# Altium schematic sheet Plumo-2D.SchDoc
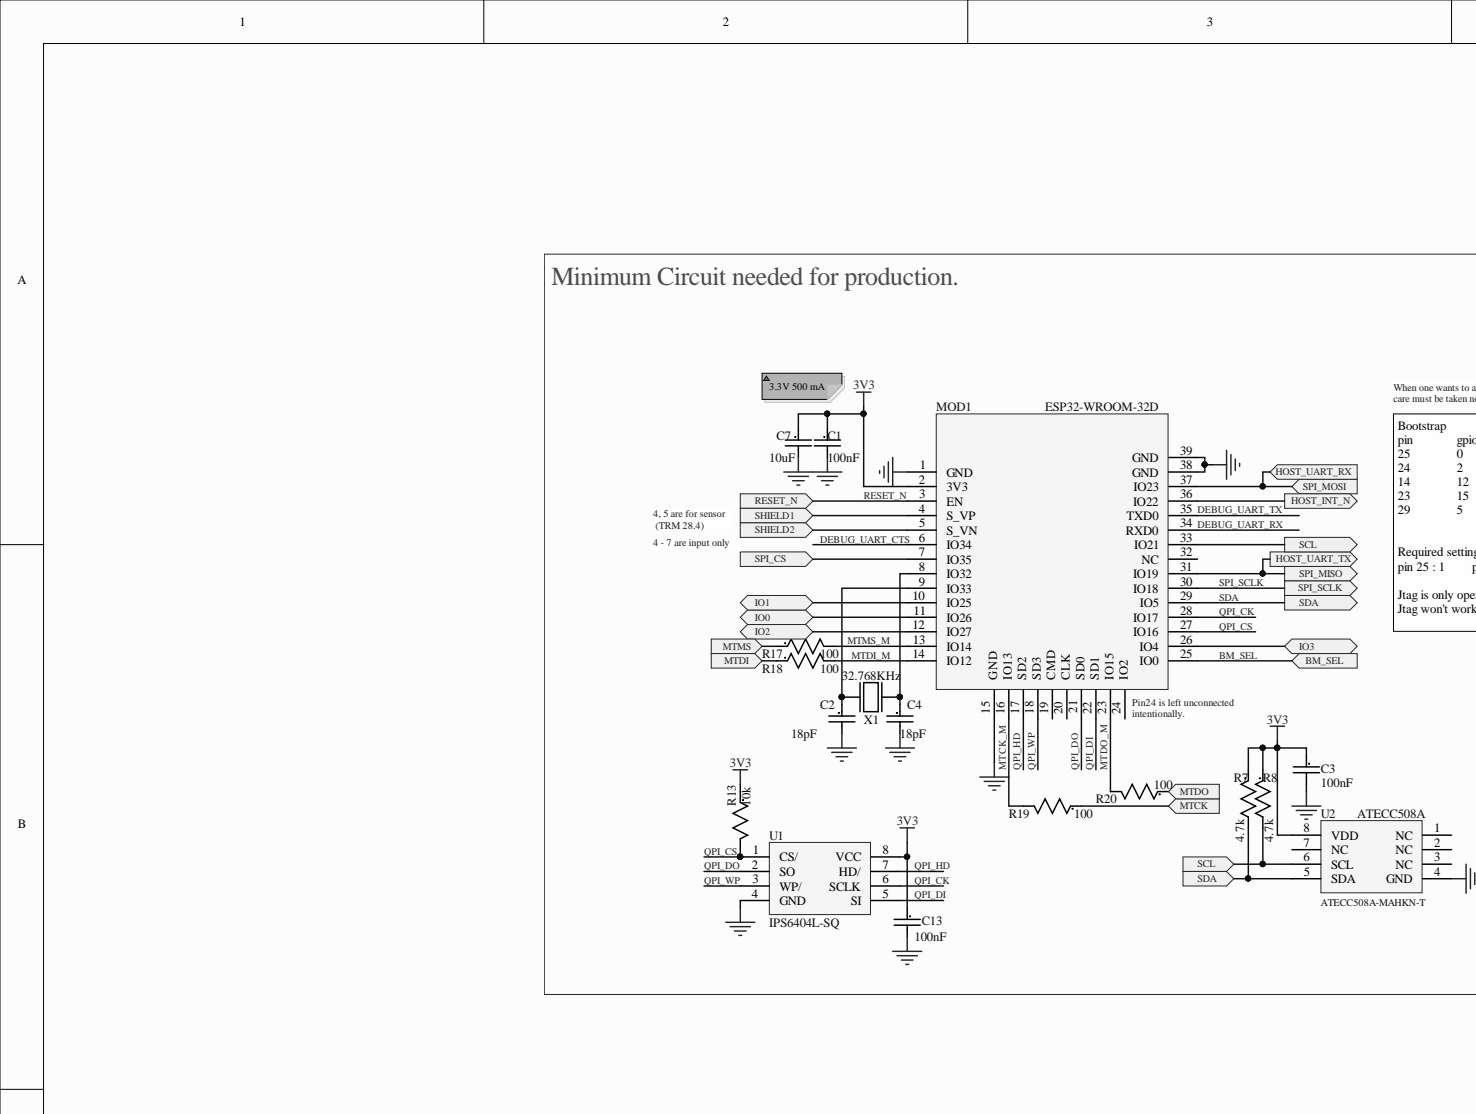
[diagram p0: Plumo-2D.SchDoc, top left]
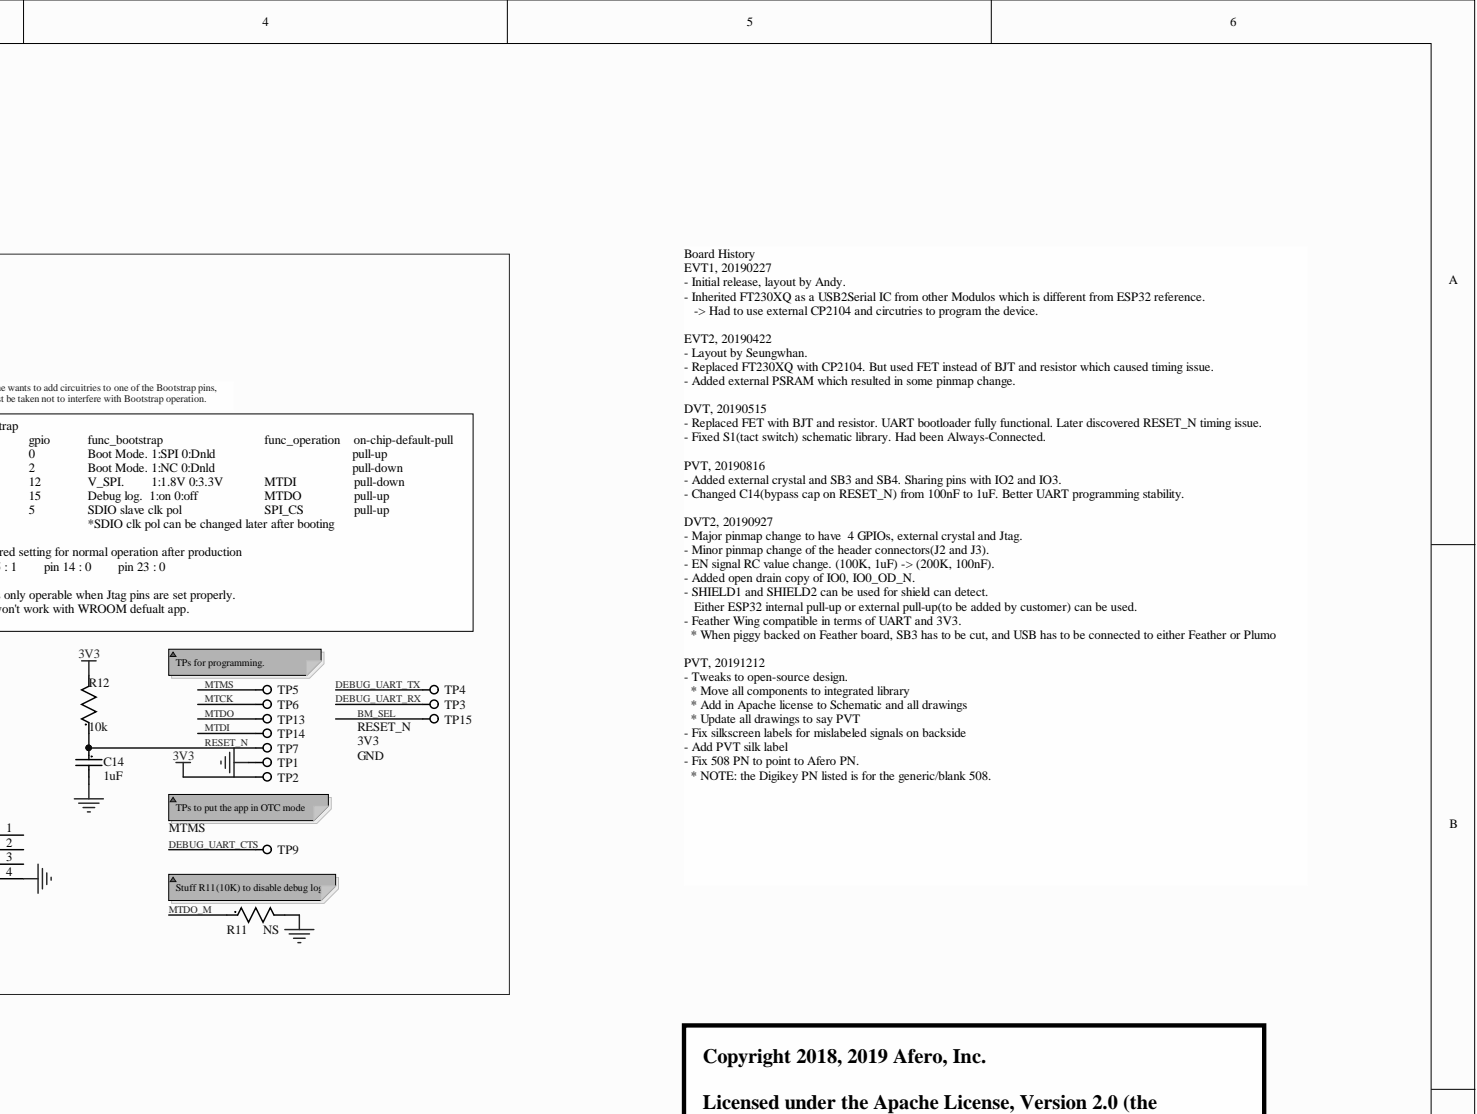
[diagram p1: Plumo-2D.SchDoc, top right]
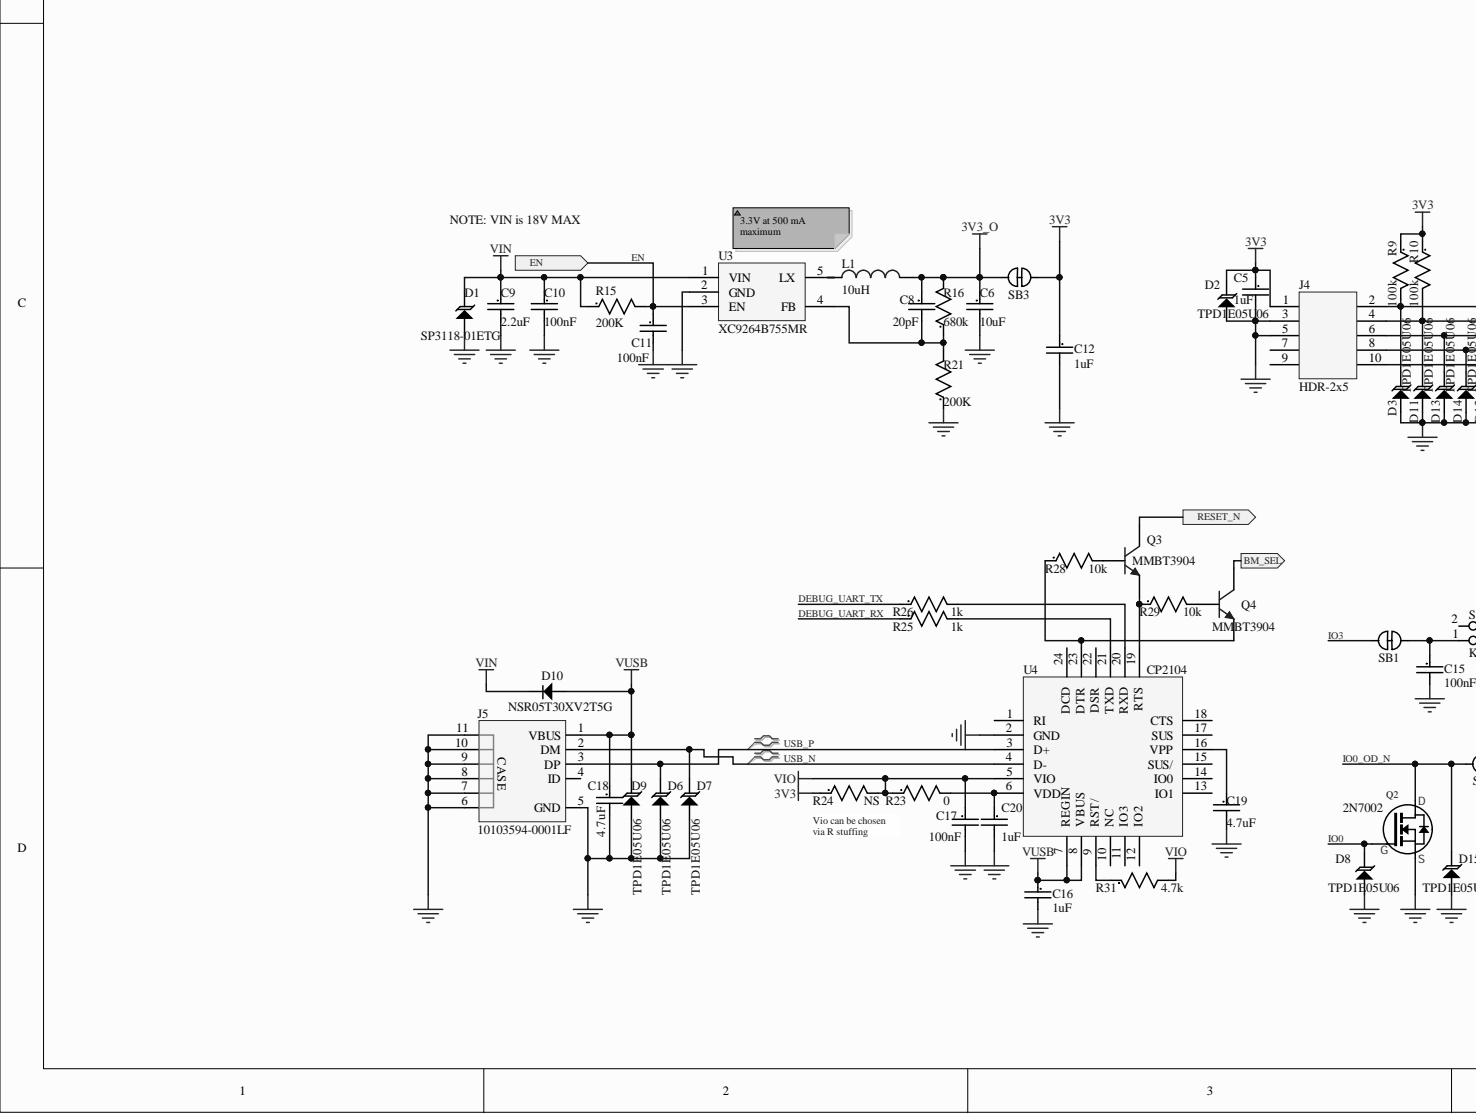
[diagram p2: Plumo-2D.SchDoc, bottom left]
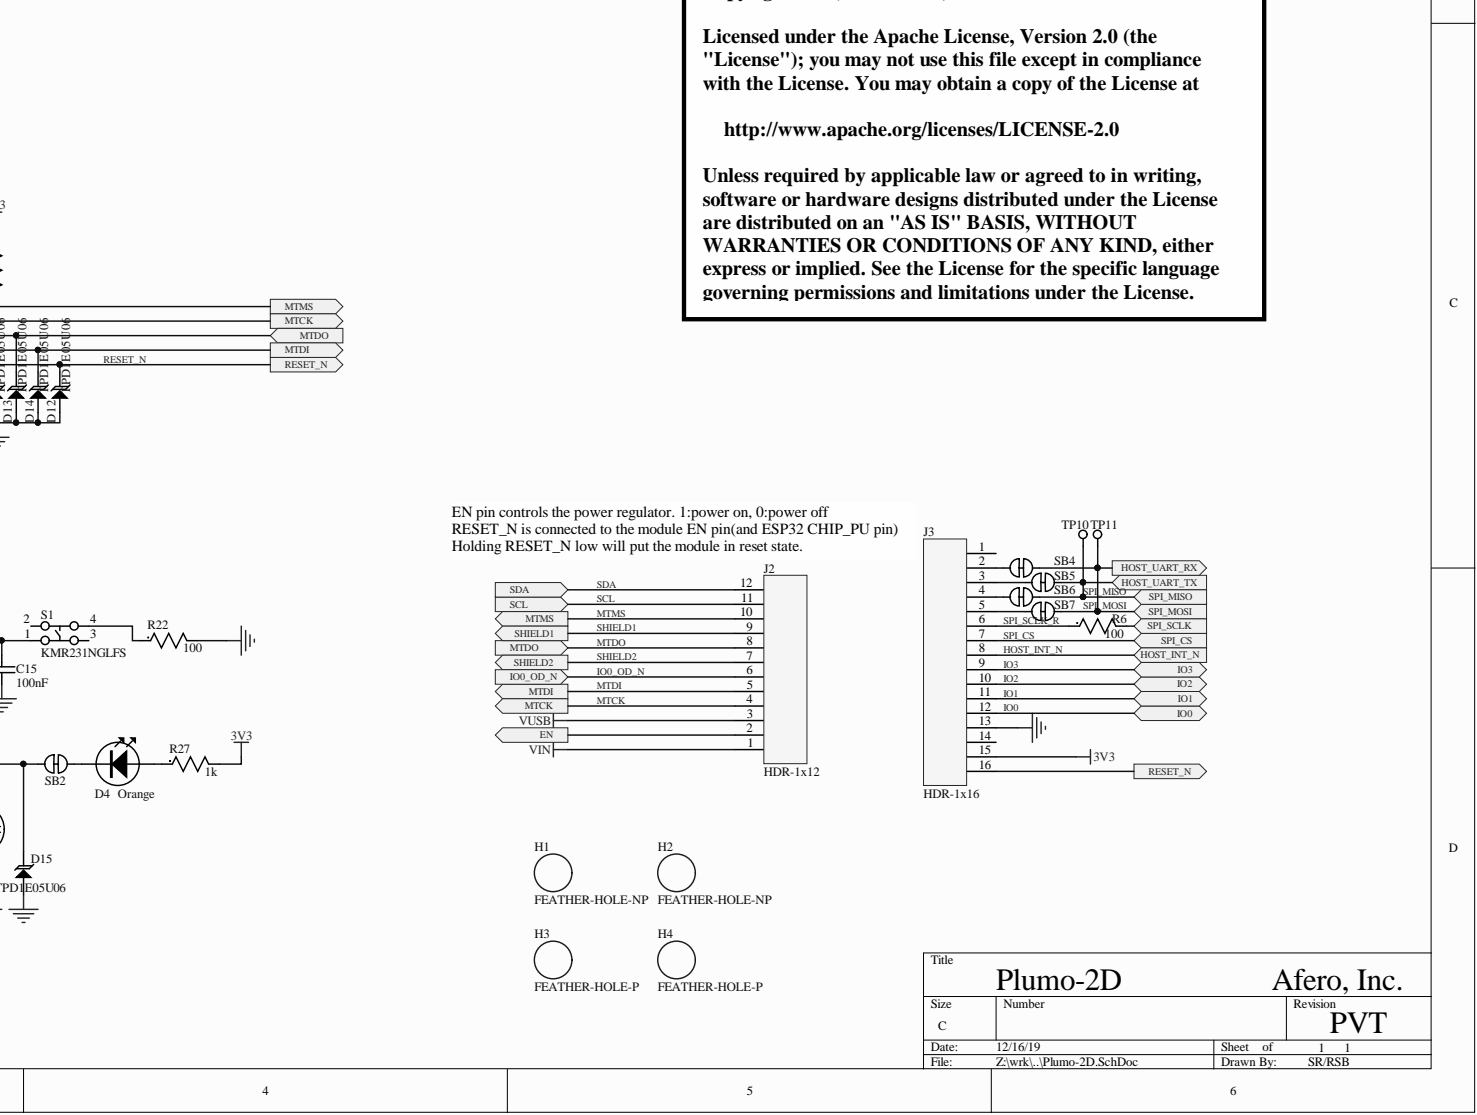
[diagram p3: Plumo-2D.SchDoc, bottom right]

## Parts
C1  100nF  [0402C-GENERIC]
C2  18pF  [0402C-GENERIC]
C3  100nF  [0402C-GENERIC]
C4  18pF  [0402C-GENERIC]
C5  1uF  [0402C-GENERIC]
C6  10uF  [0603C-GENERIC]
C7  10uF  [0603C-GENERIC]
C8  20pF  [0402C-GENERIC]
C9  2.2uF  [0603C-GENERIC]
C10  100nF  [0402C-GENERIC]
C11  100nF  [0402C-GENERIC]
C12  1uF  [0402C-GENERIC]
C13  100nF  [0402C-GENERIC]
C14  1uF  [0402C-GENERIC]
C15  100nF  [0402C-GENERIC]
C16  1uF  [0402C-GENERIC]
C17  100nF  [0402C-GENERIC]
C18  4.7uF  [0603C-GENERIC]
C19  4.7uF  [0603C-GENERIC]
C20  1uF  [0402C-GENERIC]
D1  SP3118-01ETG  [SOD882]
D2  TPD1E05U06  [SON70P100X45-2N]
D3  TPD1E05U06  [SON70P100X45-2N]
D4  Orange  [0603LED]
D6  TPD1E05U06  [SON70P100X45-2N]
D7  TPD1E05U06  [SON70P100X45-2N]
D8  TPD1E05U06  [SON70P100X45-2N]
D9  TPD1E05U06  [SON70P100X45-2N]
D10  NSR05T30XV2T5G  [SOD-523]
D11  TPD1E05U06  [SON70P100X45-2N]
D12  TPD1E05U06  [SON70P100X45-2N]
D13  TPD1E05U06  [SON70P100X45-2N]
D14  TPD1E05U06  [SON70P100X45-2N]
D15  TPD1E05U06  [SON70P100X45-2N]
H1  FEATHER-HOLE-NP  [FEATHER-HOLE-NP]  (no BOM)
H2  FEATHER-HOLE-NP  [FEATHER-HOLE-NP]  (no BOM)
H3  FEATHER-HOLE-P  [FEATHER-HOLE-P]  (no BOM)
H4  FEATHER-HOLE-P  [FEATHER-HOLE-P]  (no BOM)
J2  HDR-1x12  [HDR-1X12]
J3  HDR-1x16  [HDR-1X16]
J4  HDR-2x5  [S9015E-05]
J5  10103594-0001LF  [10103594-0001LF]
L1  10uH  [ASPI-0315FS]
MOD1  ESP32-WROOM-32D  [ESP32-WROOM-32D]
Q2  2N7002  [SOT23]
Q3  MMBT3904  [sot23]
Q4  MMBT3904  [sot23]
R6  100  [0402R-GENERIC]
R7  4.7k  [0402R-GENERIC]
R8  4.7k  [0402R-GENERIC]
R9  100k  [0402R-GENERIC]
R10  100k  [0402R-GENERIC]
R11  NS  [0402R-GENERIC]  (no BOM)
R12  10k  [0402R-GENERIC]
R13  10k  [0402R-GENERIC]
R15  200K  [0402R-GENERIC]
R16  680k  [0402R-GENERIC]
R17  100  [0402R-GENERIC]
R18  100  [0402R-GENERIC]
R19  100  [0402R-GENERIC]
R20  100  [0402R-GENERIC]
R21  200K  [0402R-GENERIC]
R22  100  [0402R-GENERIC]
R23  0  [0402R-GENERIC]
R24  NS  [0402R-GENERIC]  (no BOM)
R25  1k  [0402R-GENERIC]
R26  1k  [0402R-GENERIC]
R27  1k  [0402R-GENERIC]
R28  10k  [0402R-GENERIC]
R29  10k  [0402R-GENERIC]
R31  4.7k  [0402R-GENERIC]
S1  KMR231NGLFS  [KMR231NGLFS]
SB1  Solderbridge  [SBNC]  (value not drawn)
SB2  Solderbridge  [SBNC]  (value not drawn)
SB3  Solderbridge  [SBNC]  (value not drawn)
SB4  Solderbridge  [SBNC]  (value not drawn)
SB5  Solderbridge  [SBNC]  (value not drawn)
SB6  Solderbridge  [SBNC]  (value not drawn)
SB7  Solderbridge  [SBNC]  (value not drawn)
TP1  TP1MM  [TP1MM]  (value not drawn)  (no BOM)
TP2  TP1MM  [TP1MM]  (value not drawn)  (no BOM)
TP3  TP1MM  [TP1MM]  (value not drawn)  (no BOM)
TP4  TP1MM  [TP1MM]  (value not drawn)  (no BOM)
TP5  TP1MM  [TP1MM]  (value not drawn)  (no BOM)
TP6  TP1MM  [TP1MM]  (value not drawn)  (no BOM)
TP7  TP1MM  [TP1MM]  (value not drawn)  (no BOM)
TP9  TP1MM  [TP1MM]  (value not drawn)  (no BOM)
TP10  TP1MM  [TP1MM]  (value not drawn)  (no BOM)
TP11  TP1MM  [TP1MM]  (value not drawn)  (no BOM)
TP13  TP1MM  [TP1MM]  (value not drawn)  (no BOM)
TP14  TP1MM  [TP1MM]  (value not drawn)  (no BOM)
TP15  TP1MM  [TP1MM]  (value not drawn)  (no BOM)
U1  IPS6404L-SQ  [IPUS.PSRAM]
U2  ATECC508A  Manufacturer Part Number: ATECC508A-MAHKN-T  [SON50P300X60-8N]
U3  XC9264B755MR  [SOT95P275X130-5N]
U4  CP2104  [CP2104]
X1  32.768KHz  [ABS06]

## Ports
BM_SEL, EN, HOST_INT_N, HOST_UART_RX, HOST_UART_TX, IO0, IO0_OD_N, IO1, IO2, IO3, MTCK, MTDI, MTDO, MTMS, RESET_N, SCL, SDA, SHIELD1, SHIELD2, SPI_CS, SPI_MISO, SPI_MOSI, SPI_SCLK

## Nets
3V3: C1.1 C3.1 C5.1 C7.1 C12.1 C13.1 D2.1 J3.15 J4.1 MOD1.2(3V3) R7.1 R8.1 R9.1 R10.1 R12.2 R13.1 R24.1 R27.2 SB3.1 TP2.1 U1.8(VCC) U2.8(VDD)
3V3_O: C6.1 C8.1 L1.2 R16.2 SB3.2
GND: C1.2 C2.2 C3.2 C4.2 C5.2 C6.2 C7.2 C9.2 C10.2 C11.2 C12.2 C13.2 C14.2 C15.2 C16.2 C17.2 C18.2 C19.2 C20.2 D1.2 D2.2 D3.2 D6.2 D7.2 D8.2 D9.2 D11.2 D12.2 D13.2 D14.2 D15.2 J3.13 J4.3 J4.5 J5.5(GND) J5.6 J5.7 J5.8 J5.9 J5.10 J5.11 MOD1.1(GND) MOD1.15(GND) MOD1.38(GND) MOD1.39(GND) Q2.2 R11.2 R21.1 R22.2 TP1.1 U1.4(GND) U2.4(GND) U3.2(GND) U4.2(GND)
VIN: C9.1 C10.1 D1.1 D10.1 J2.1 R15.1 U3.1(VIN)
VIO: C17.1 R23.1 R24.2 R31.2 U4.5(VIO)
VUSB: C16.1 C18.1 D9.1 D10.2 J2.3 J5.1(VBUS) U4.7(REGIN) U4.8(VBUS)
BM_SEL: MOD1.25(IO0) Q4.3 TP15.1 ; port BM_SEL
DEBUG_UART_CTS: MOD1.6(IO34) TP9.1
DEBUG_UART_RX: MOD1.34(RXD0) R25.1 TP3.1
DEBUG_UART_TX: MOD1.35(TXD0) R26.1 TP4.1
EN: C11.1 J2.2 R15.2 U3.3(EN) ; port EN
HOST_INT_N: J3.8 MOD1.36(IO22) ; port HOST_INT_N
IO0: D8.1 J3.12 MOD1.11(IO26) Q2.1 ; port IO0
IO0_OD_N: D15.1 J2.6 Q2.3 SB2.1 ; port IO0_OD_N
IO1: J3.11 MOD1.10(IO25) ; port IO1
IO2: J3.10 MOD1.12(IO27) ; port IO2
IO3: J3.9 MOD1.26(IO4) SB1.1 ; port IO3
MTCK: D11.1 J2.4 J4.4 R10.2 R19.1 TP6.1 ; port MTCK
MTCK_M: MOD1.16(IO13) R19.2
MTDI: D14.1 J2.5 J4.8 R18.1 TP14.1 ; port MTDI
MTDI_M: MOD1.14(IO12) R18.2
MTDO: D13.1 J2.8 J4.6 R20.1 TP13.1 ; port MTDO
MTDO_M: MOD1.23(IO15) R11.1 R20.2
MTMS: D3.1 J2.10 J4.2 R9.2 R17.1 TP5.1 ; port MTMS
MTMS_M: MOD1.13(IO14) R17.2
QPI_CK: MOD1.28(IO17) U1.6(SCLK)
QPI_CS: MOD1.27(IO16) R13.2 U1.1(CS/)
QPI_DI: MOD1.22(SD1) U1.5(SI)
QPI_DO: MOD1.21(SD0) U1.2(SO)
QPI_HD: MOD1.17(SD2) U1.7(HD/)
QPI_WP: MOD1.18(SD3) U1.3(WP/)
RESET_N: C14.1 D12.1 J3.16 J4.10 MOD1.3(EN) Q3.3 R12.1 TP7.1 ; port RESET_N
SCL: J2.11 MOD1.33(IO21) R8.2 U2.6(SCL) ; port SCL
SDA: J2.12 MOD1.29(IO5) R7.2 U2.5(SDA) ; port SDA
SHIELD1: J2.9 MOD1.4(S_VP) ; port SHIELD1
SHIELD2: J2.7 MOD1.5(S_VN) ; port SHIELD2
SPI_CS: J3.7 MOD1.7(IO35) ; port SPI_CS
SPI_MISO: MOD1.31(IO19) SB5.2 SB6.2 TP10.1 ; port HOST_UART_TX, port SPI_MISO
SPI_MOSI: MOD1.37(IO23) SB4.2 SB7.2 TP11.1 ; port HOST_UART_RX, port SPI_MOSI
SPI_SCLK: MOD1.30(IO18) R6.2 ; port SPI_SCLK
SPI_SCLK_R: J3.6 R6.1
USB_N: D7.1 J5.2(DM) U4.4(D-)
USB_P: D6.1 J5.3(DP) U4.3(D+)
NetC2_1: C2.1 MOD1.9(IO33) X1.2
NetC4_1: C4.1 MOD1.8(IO32) X1.1
NetC8_2: C8.2 R16.1 R21.2 U3.4(FB)
NetC15_1: C15.1 S1.1 SB1.2
NetC19_1: C19.1 U4.16(VPP)
NetC20_1: C20.1 R23.2 U4.6(VDD)
NetD4_A: D4.A R27.1
NetD4_K: D4.K SB2.2
NetJ3_2: J3.2 SB4.1
NetJ3_3: J3.3 SB5.1
NetJ3_4: J3.4 SB6.1
NetJ3_5: J3.5 SB7.1
NetL1_1: L1.1 U3.5(LX)
NetQ3_1: Q3.1 R28.2
NetQ3_2: Q3.2 R29.1 U4.19(RTS)
NetQ4_1: Q4.1 R29.2
NetQ4_2: Q4.2 R28.1 U4.23(DTR)
NetR22_1: R22.1 S1.4
NetR25_2: R25.2 U4.21(TXD)
NetR26_2: R26.2 U4.20(RXD)
NetR31_1: R31.1 U4.9(RST/)

Not connected: J3 1 14; J4 7 9; J5 4(ID); MOD1 19(CMD) 20(CLK) 24(IO2) 32(NC); S1 2 3; U2 1(NC) 2(NC) 3(NC) 7(NC); U4 1(RI) 10(NC) 11(IO3) 12(IO2) 13(IO1) 14(IO0) 15(SUS/) 17(SUS) 18(CTS) 22(DSR) 24(DCD)

## Library data of the parts
C1 C3 C10 C11 C13 C15 C17 = 0402C-100n: Capacitor 100nF X5R 50V 10% 0402; Manufacturer: Murata; Manufacturer Part Number: GRM155R61H104KE19D; item_number: E-CAP-00090-00; Capacitance: 100nF; Case/Package: 0402; Case Code (Imperial): 0402; Case Code (Metric): 1005; Component Kind: Standard; Depth: 0.5mm; Dielectric: X5R; Height: 0.5mm; Lead Free: Lead Free; Length: 1mm; Max Operating Temperature: 85°C; Min Operating Temperature: -55°C; Mount: Surface Mount; Packaging: Tape and Reel; Pricing 1: 1=0.1, 10=0.068, 50=0.068, 100=0.03, 1000=0.017, 10000=0.011 (USD); REACH SVHC: No SVHC; Stock 1: 727844; Supplier 1: Mouser; Supplier Part Number 1: 81-GRM155R61H104KE9D; Thickness: 0.022inch; Tolerance: 10%; Voltage: 50V; Voltage Rating: 50V; Voltage Rating (DC): 50V; Width: 0.02inch; Library Name: Plumo-2D.IntLib
C2 C4 = 0402C-18p: Capacitor, 18pF, 50V, 1%, C0G; Manufacturer: Murata; Manufacturer Part Number: GJM1555C1H180FB01D; item_number: E-CAP-00110-00; Capacitance: 18pF; Case/Package: 0402; Case Code (Imperial): 0402; Case Code (Metric): 1005; Component Kind: Standard; Depth: 0.5mm; Dielectric: C0G; Height: 0.5mm; Lead Free: Lead Free; Length: 1mm; Max Operating Temperature: 125°C; Min Operating Temperature: -55°C; Mount: Surface Mount; Package Quantity: 10000; Packaging: Tape and Reel; Radiation Hardening: No; REACH SVHC: No SVHC; Termination: SMD/SMT; Thickness: 0.022inch; Tolerance: 1%; Voltage Rating: 50V; Voltage Rating (DC): 50V; Width: 0.02inch; Library Name: Plumo-2D.IntLib
C5 C12 C14 C16 C20 = 0402C-1u: Capacitor 1uF X5R 6.3V 20% 0402; Manufacturer Part Number: CL05A105KQ5NNNC; Brand: SEM; Capacitance - nF: 1000 nF; item_number: E-CAP-00020-00; Type: Chip Monolithic Ceramic Capacitor; Value: 1u; Voltage Rating DC: 6.3 VDC; Capacitance: 1uF; Case Code - in: 0402; Case Code - mm: 1005; Category: Multilayer Ceramic Capacitors MLCC - SMD/SMT; Component Kind: Standard; Dielectric: X5R; Height: 0.5 mm; Length: 1 mm; Manufacturer: SEM; Maximum Operating Temperature: + 85 C; Minimum Operating Temperature: - 55 C; Minimum Order Quantity: 1; Package / Case: 0402 (1005 metric); Packaging: Cut Tape; Product: General Type MLCCs; Reeling: True; RoHS: RoHS Compliant; RoHS - Mouser: Y; Series: GRM; Standard Pack Qty: 50000; Termination Style: SMD/SMT; Tolerance: 20 %; Width: 0.5 mm; Library Name: Plumo-2D.IntLib
C6 C7 = 0603C-10u: Capacitor 10uF X5R 16V 20% 0603; Manufacturer: Murata; Manufacturer Part Number: GRM188R61C106MA73D; item_number: E-CAP-00100-00; Material: Ceramic; Value: 10u; Capacitance: 10uF; Case/Package: 0603; Case Code (Imperial): 0603; Case Code (Metric): 1608; Component Kind: Standard; Depth: 0.8mm; Dielectric: X5R; Height: 1mm; Lead Free: Lead Free; Length: 1.6mm; Max Operating Temperature: 85°C; Min Operating Temperature: -55°C; Mount: Surface Mount; Package Quantity: 4000; Packaging: Tape and Reel; REACH SVHC: No SVHC; Termination: SMD/SMT; Thickness: 0.037inch; Tolerance: 20%; Voltage Rating: 16V; Voltage Rating (DC): 16V; Weight: 0.000222oz; Width: 0.8mm; Library Name: Plumo-2D.IntLib
C8 = 0402C-20p: Capacitor 20pF C0G 50V 1% 0402; Manufacturer: Murata; Manufacturer Part Number: GJM1555C1H200FB01D; item_number: E-CAP-00107-00; Capacitance: 20pF; Case/Package: 0402; Case Code (Imperial): 0402; Case Code (Metric): 1005; Component Kind: Standard; Depth: 0.5mm; Dielectric: C0G; Height: 0.5mm; Lead Free: Lead Free; Length: 1mm; Max Operating Temperature: 125°C; Min Operating Temperature: -55°C; Mount: Surface Mount; Package Quantity: 10000; Packaging: Tape and Reel; Radiation Hardening: No; REACH SVHC: No SVHC; Termination: SMD/SMT; Thickness: 0.022inch; Tolerance: 1%; Voltage Rating: 50V; Voltage Rating (DC): 50V; Width: 0.02inch; Library Name: Plumo-2D.IntLib
C9 = 0603C-2u2: Capacitor 2.2uF X5R 25V 10% 0603; Manufacturer: Murata; Manufacturer Part Number: GRM188R61E225KA12D; item_number: E-CAP-00108-00; Material: Ceramic; Value: 2u2; Capacitance: 2.2uF; Case/Package: 0603; Case Code (Imperial): 0603; Case Code (Metric): 1608; Component Kind: Standard; Depth: 0.8mm; Dielectric: X5R; Height: 0.9mm; Lead Free: Lead Free; Length: 1.6mm; Max Operating Temperature: 85°C; Min Operating Temperature: -55°C; Mount: Surface Mount; Package Quantity: 4000; Packaging: Tape and Reel; REACH SVHC: No SVHC; Termination: SMD/SMT; Thickness: 0.037inch; Tolerance: 10%; Voltage Rating: 25V; Voltage Rating (DC): 25V; Weight: 0.000222oz; Width: 0.8mm; Library Name: Plumo-2D.IntLib
C18 C19 = 0603C-4u7: Capacitor 4.7uF X5R 10V 10% 0603; Manufacturer: Taiyo Yuden; Manufacturer Part Number: LMK107BJ475KA-T; item_number: E-CAP-00066-00; Material: Ceramic; Value: 4u7; Capacitance: 4.7uF; Case/Package: 0603; Case Code (Imperial): 0603; Case Code (Metric): 1608; Component Kind: Standard; Depth: 0.8mm; Dielectric: X5R; Height: 0.9mm; Lead Free: Lead Free; Length: 1.6mm; Max Operating Temperature: 85°C; Min Operating Temperature: -55°C; Mount: Surface Mount; Package Quantity: 4000; Packaging: Tape and Reel; REACH SVHC: No SVHC; Termination: SMD/SMT; Thickness: 0.035inch; Tolerance: 10%; Voltage Rating: 10V; Voltage Rating (DC): 10V; Weight: 0.000222oz; Width: 0.8mm; Library Name: Plumo-2D.IntLib
D1 = SP3118-01ETG: Diode TVS; Manufacturer: Littelfuse; Capacitance: 0.3pF; Clamping Voltage: 38V; Direction: Bidirectional; Element Configuration: Single; item_number: E-DIO-00011-00; Leakage Current: 50nA; Max Power Dissipation: 76W; Number of Bidirectional Channels: 1; Operating Supply Voltage: 18V; Peak Pulse Current: 2A; Power Line Protection: Yes; Component Kind: Standard; Lead Free: Lead Free; Length: 1mm; Manufacturer Part Number: SP3118-01ETG; Max Operating Temperature: 125°C; Min Breakdown Voltage: -; Min Operating Temperature: -40°C; Mount: Surface Mount; Number of Channels: 1; Number of Pins: 2; Package Quantity: 10000; Packaging: Tape and Reel; Peak Pulse Power: 76W; Polarity: Bidirectional; Radiation Hardening: No; REACH SVHC: No SVHC; Reverse Standoff Voltage: 18V; Termination: SMD/SMT; Working Voltage: 18V; Library Name: Plumo-2D.IntLib
D2 D3 D6-D9 D11-D15 = TPD1E05U06: Diode TVS; Manufacturer: Texas Instruments; Manufacturer Part Number: TPD1E05U06DPYR; Breakdown Voltage: 6.5V; Capacitance: 0.5pF; Clamping Voltage: 14V; Direction: Unidirectional; Element Configuration: Single; Interface: LVDS,USB,Ethernet,HDMI,PCIe,SATA; item_number: E-DIO-00008-00; Leakage Current: 10nA; Max Power Dissipation: 40W; Min Breakdown Voltage: 6V; Number of Bidirectional Channels: 1; Operating Supply Voltage: 5.5V; Peak Pulse Current: 2.5A; Polarity: Bidirectional; Power Line Protection: Yes; Component Kind: Standard; ComponentLink1Description: https://datasheet.ciiva.com/12545/tpd1e05u06-224685-12545140.pdf; ComponentLink1URL: https://datasheet.ciiva.com/12545/tpd1e05u06-224685-12545140.pdf; ComponentLink2Description: https://datasheet.ciiva.com/26918/getdatasheetpartid-700473-26918022.pdf; ComponentLink2URL: https://datasheet.ciiva.com/26918/getdatasheetpartid-700473-26918022.pdf; ComponentLink3Description: https://datasheet.ciiva.com/6574/tpd1e05u06-6574667.pdf; ComponentLink3URL: https://datasheet.ciiva.com/6574/tpd1e05u06-6574667.pdf; Lead Free: Lead Free; Length: 1.1mm; Max Operating Temperature: 125°C; Min Operating Temperature: -40°C; Mount: Surface Mount; Number of Channels: 1; Number of Pins: 2; Package Quantity: 10000; Packaging: Tape and Reel; Peak Pulse Power: 40W; Pricing 1: 1=0.5, 10=0.372, 100=0.2107, 500=0.1395, 1000=0.107 (USD); Radiation Hardening: No; REACH SVHC: No SVHC; Reverse Standoff Voltage: 5.5V; Stock 1: 161045; Supplier 1: Digi-Key; Supplier Part Number 1: 296-39341-1-ND; Termination: SMD/SMT; Working Voltage: 5.5V; Library Name: Plumo-2D.IntLib
D4 = LED_0603_O: LED Orange 0603; Manufacturer: Vishay Lite-On; Manufacturer Part Number: LTST-C190KAKT; Colour: Red,Orange; Dominant Wavelength: 615nm; Forward Current: 30mA; Forward Voltage: 2V; item_number: E-LED-00012-00; Lens Colour: Colorless; Lens Style: Flat,Diffused,Colorless,Rectangular; Lens Transparency: Clear; Luminous Intensity: 54mcd; Number of Elements: 1; Power Dissipation: 75mW; Reverse Voltage: 5V; Test Current: 20mA; Viewing Angle: 130°; Wavelength: 621nm; Case/Package: 0603; Case Code (Imperial): 0603; Case Code (Metric): 1608; Component Kind: Standard; ComponentLink1Description: https://datasheet.ciiva.com/24120/ltst-c190kakt-267511-24120611.pdf; ComponentLink1URL: https://datasheet.ciiva.com/24120/ltst-c190kakt-267511-24120611.pdf; ComponentLink2Description: https://datasheet.ciiva.com/26937/getdatasheetpartid-257177-26937956.pdf; ComponentLink2URL: https://datasheet.ciiva.com/26937/getdatasheetpartid-257177-26937956.pdf; ComponentLink3Description: https://datasheet.ciiva.com/2110/ltst-c150-170-190kxkt-2110261.pdf; ComponentLink3URL: https://datasheet.ciiva.com/2110/ltst-c150-170-190kxkt-2110261.pdf; ComponentLink4Description: https://datasheet.ciiva.com/2110/ltst-c150-170-190kxkt-2110262.pdf; ComponentLink4URL: https://datasheet.ciiva.com/2110/ltst-c150-170-190kxkt-2110262.pdf; ComponentLink5Description: https://datasheet.ciiva.com/2110/ltst-c150-170-190kxkt-2110263.pdf; ComponentLink5URL: https://datasheet.ciiva.com/2110/ltst-c150-170-190kxkt-2110263.pdf; Depth: 1.6mm; Height: 0.8mm; Illumination Colour: Orange; Lead Free: Lead Free; Length: 1.6mm; Max Operating Temperature: 85°C; Min Operating Temperature: -55°C; Mount: Surface Mount; Number of LEDs: 1; Number of Pins: 2; Orientation: Top View; Package Quantity: 1; Packaging: Tape and Reel; Pricing 1: 1=0.41, 10=0.296, 25=0.2268, 100=0.148, 250=0.1085, 500=0.0921, 1000=0.0757 (USD); Radiation Hardening: No; Stock 1: 60967; Supplier 1: Digi-Key; Supplier Part Number 1: 160-1433-1-ND; Width: 0.8mm; Library Name: Plumo-2D.IntLib
D10 = NSR05T30XV2T5G: Diode Schottky 30V 0.5A SOD523; Manufacturer: On Semiconductor; Direction: Unidirectional; Element Configuration: Single; item_number: E-DIO-00012-00; Leakage Current: 170uA/30V; Number of Channels: 1; Peak Pulse Current: 1.5A; Component Kind: Standard; Lead Free: Lead Free; Length: 1.6mm; Manufacturer Part Number: NSR05T30XV2T5G; Max Operating Temperature: 150°C; Max Reverse Voltage (DC): 30V; Min Operating Temperature: -55°C; Mount: Surface Mount; Number of Pins: 2; Package / Case: SOD523; Package Quantity: 10000; Packaging: Tape and Reel; Radiation Hardening: No; REACH SVHC: No SVHC; Termination: SMD/SMT; Voltage Rating (DC): 30V; Library Name: Plumo-2D.IntLib
H1 H2 = FEATHER-HOLE-NP: 0.09" Unplated Hole; Library Name: Plumo-2D.IntLib
H3 H4 = FEATHER-HOLE-P: 0.1" Plated Hole; Library Name: Plumo-2D.IntLib
J2 = HDR-1x12: 0.1" header 12 positions; Manufacturer: Molex; Manufacturer Part Number: 22032121; item_number: M-CON-00086-00; Component Kind: Standard; Library Name: Plumo-2D.IntLib
J3 = HDR-1x16: 0.1" header 16 positions; Manufacturer: Molex; Manufacturer Part Number: 22032161; item_number: M-CON-00087-00; Component Kind: Standard; Library Name: Plumo-2D.IntLib
J4 = HDR-2x5: .050 Male Header 2x5; Manufacturer Part Number: GRPB052VWVN-RC; item_number: M-CON-00016-00; Component Kind: Standard; Library Name: Plumo-2D.IntLib
J5 = 10103594-0001LF: Connector MICRO USB B; Manufacturer: Amphenol FCI; Connector Type: USB,Receptacle; Current Rating: 1.8A; Gender: Receptacle; Insulation Resistance: 1GR; item_number: M-CON-00011-00; Max Voltage Rating (AC): 100V; Number of Contacts: 5; Number of Ports: 1; Pitch: 0.65mm; USB Standard: USB 2.0; Component Kind: Standard; ComponentLink1Description: https://datasheet.ciiva.com/8015/10103594-8015248.pdf; ComponentLink1URL: https://datasheet.ciiva.com/8015/10103594-8015248.pdf; ComponentLink2Description: https://datasheet.ciiva.com/11731/0900766b80ed1ae4-11731328.pdf; ComponentLink2URL: https://datasheet.ciiva.com/11731/0900766b80ed1ae4-11731328.pdf; ComponentLink3Description: https://datasheet.ciiva.com/14618/70236822-14618885.pdf; ComponentLink3URL: https://datasheet.ciiva.com/14618/70236822-14618885.pdf; ComponentLink4Description: https://datasheet.ciiva.com/22654/1469075-22654519.pdf; ComponentLink4URL: https://datasheet.ciiva.com/22654/1469075-22654519.pdf; ComponentLink5Description: https://datasheet.ciiva.com/851/10103594-851115.pdf; ComponentLink5URL: https://datasheet.ciiva.com/851/10103594-851115.pdf; ComponentLink6Description: https://datasheet.ciiva.com/5726/10103594-5726971.pdf; ComponentLink6URL: https://datasheet.ciiva.com/5726/10103594-5726971.pdf; ComponentLink7Description: https://datasheet.ciiva.com/851/10103594-851116.pdf; ComponentLink7URL: https://datasheet.ciiva.com/851/10103594-851116.pdf; ComponentLink8Description: https://datasheet.ciiva.com/851/10103594-851117.pdf; ComponentLink8URL: https://datasheet.ciiva.com/851/10103594-851117.pdf; Contact Material: Copper,Copper Alloy; Contact Plating: Gold; Features: Shielded; Housing Material: Thermoplastic; Lead Free: Lead Free; Length: 8.04mm; Manufacturer Part Number: 10103594-0001LF; Max Operating Temperature: 85°C; Min Operating Temperature: -55°C; Mount: Surface Mount,Horizontal; Number of Terminals: 5; Orientation: Right Angle; Package Quantity: 1500; Packaging: Tape and Reel; Pricing 1: 1=0.82, 10=0.721, 25=0.6788, 50=0.6504, 100=0.6221, 250=0.5655, 500=0.509 (USD); Radiation Hardening: No; REACH SVHC: No SVHC; Stock 1: 103885; Supplier 1: Digi-Key; Supplier Part Number 1: 609-4050-1-ND; Termination: Solder; Voltage Rating: 100V; Library Name: Plumo-2D.IntLib
L1 = ASPI-0315FS-100M: Inductor 10uH 1.1A 0.22ohm 20% 3x3; Manufacturer: Abracon; item_number: E-IND-00043-00; Component Kind: Standard; Manufacturer Part Number: ASPI-0315FS-100M; Value: 10uH; Library Name: Plumo-2D.IntLib
MOD1 = ESP32-WROOM-32D: Module WIFI BLE ESP32-D0WD 4MB; Manufacturer: Espressif; Item_number: E-ICS-00097-00; Component Kind: Standard; Manufacturer Part Number: ESP32-WROOM-32D; Size: 25.5mm x 18mm; Library Name: Plumo-2D.IntLib
Q2 = Nch_MOSFET_2N7002: TR MOSFET N-CH 60V 115mA SOT23; Manufacturer: On Semiconductor; Commodity: FET; item_number: E-TRS-00003-00; Component Kind: Standard; Manufacturer Part Number: 2N7002; Package / Case: SOT23; Value: 2N7002; Library Name: Plumo-2D.IntLib
Q3 Q4 = MMBT3904: TR NPN BJT 200mA 40V; Manufacturer: On Semiconductor; Manufacturer Part Number: MMBT3904LT1G; item_number: E-TRS-00002-00; Component Kind: Standard; ComponentLink1Description: Manufacturer Link; ComponentLink1URL: http://www.fairchildsemi.com/; ComponentLink2Description: Datasheet; ComponentLink2URL: http://www.fairchildsemi.com/ds/2N%2F2N3904.pdf; Package/Case: SOT23; Library Name: Plumo-2D.IntLib
R6 R17-R20 R22 = 0402R-100R: Resistor 100R 50V 5% 0402; Manufacturer: Panasonic; Manufacturer Part Number: ERJ-2GEJ101X; item_number: E-RES-00008-00; Max Power Dissipation: 100mW; Temperature Coefficient: 100ppm/°C; Case/Package: 0402; Case Code (Imperial): 0402; Case Code (Metric): 1005; Component Kind: Standard; Composition: Thick Film; Contact Plating: Tin; Depth: 0.5mm; Features: Automotive AEC-Q200; Height: 0.35mm; Lead Free: Lead Free; Length: 1mm; Max Operating Temperature: 155°C; Military Standard: Not; Min Operating Temperature: -55°C; Mount: Surface Mount; Number of Terminations: 2; Package Quantity: 10; Packaging: Tape and Reel; Power Rating: 100mW; Pricing 1: 1=0.1, 10=0.044, 100=0.0178, 1000=0.008, 2500=0.007, 5000=0.0058 (USD); Radiation Hardening: No; REACH SVHC: Unknown; Resistance: 100; Termination: SMD/SMT; Tolerance: 5%; Voltage Rating: 50V; Voltage Rating (DC): 50V; Weight: 2.3E-05oz; Width: 0.02inch; Working Voltage: 50V; Library Name: Plumo-2D.IntLib
R7 R8 R31 = 0402R-4k7: Resistor 4.7K 50V 5% 0402; Manufacturer: Panasonic; Manufacturer Part Number: ERJ-2GEJ472X; item_number: E-RES-00039-00; Max Power Dissipation: 100mW; Temperature Coefficient: 200ppm/°C; Case/Package: 0402; Case Code (Imperial): 0402; Case Code (Metric): 1005; Component Kind: Standard; ComponentLink1Description: https://datasheet.ciiva.com/11821/0900766b80f806dd-11821328.pdf; ComponentLink1URL: https://datasheet.ciiva.com/11821/0900766b80f806dd-11821328.pdf; ComponentLink2Description: https://datasheet.ciiva.com/16758/70260739-16758341.pdf; ComponentLink2URL: https://datasheet.ciiva.com/16758/70260739-16758341.pdf; ComponentLink3Description: https://datasheet.ciiva.com/16770/70260739-16770309.pdf; ComponentLink3URL: https://datasheet.ciiva.com/16770/70260739-16770309.pdf; ComponentLink4Description: https://datasheet.ciiva.com/28514/1678796-28514168.pdf; ComponentLink4URL: https://datasheet.ciiva.com/28514/1678796-28514168.pdf; ComponentLink5Description: https://datasheet.ciiva.com/17213/aoa0000ce1-17213178.pdf; ComponentLink5URL: https://datasheet.ciiva.com/17213/aoa0000ce1-17213178.pdf; ComponentLink6Description: https://datasheet.ciiva.com/16523/aoa0000ce1-16523499.pdf; ComponentLink6URL: https://datasheet.ciiva.com/16523/aoa0000ce1-16523499.pdf; ComponentLink7Description: https://datasheet.ciiva.com/46377/1678796-46377565.pdf; ComponentLink7URL: https://datasheet.ciiva.com/46377/1678796-46377565.pdf; Composition: Thick Film; Contact Plating: Tin; Depth: 0.5mm; Features: Automotive AEC-Q200; Height: 0.35mm; Lead Free: Lead Free; Length: 1mm; Max Operating Temperature: 155°C; Military Standard: Not; Min Operating Temperature: -55°C; Mount: Surface Mount; Number of Terminations: 2; Package Quantity: 1; Packaging: Cut Tape; Power Rating: 100mW; Pricing 1: 1=0.1, 10=0.038, 100=0.0154, 1000=0.0069, 2500=0.006, 5000=0.005 (USD); Radiation Hardening: No; REACH SVHC: No SVHC; Resistance: 4.7k; Stock 1: 10404054; Supplier 1: Digi-Key; Supplier Part Number 1: P4.7KJCT-ND; Termination: SMD/SMT; Tolerance: 5%; Voltage Rating: 50V; Voltage Rating (DC): 50V; Width: 0.5mm; Library Name: Plumo-2D.IntLib
R9 R10 = 0402R-100k: Resistor 100K 50V 5% 0402; Manufacturer: Panasonic; Manufacturer Part Number: ERJ-2GEJ104X; item_number: E-RES-00012-00; Max Power Dissipation: 100mW; Temperature Coefficient: 200ppm/°C; Case/Package: 0402; Case Code (Imperial): 0402; Case Code (Metric): 1005; Component Kind: Standard; ComponentLink1Description: https://datasheet.ciiva.com/11821/0900766b80f806dd-11821174.pdf; ComponentLink1URL: https://datasheet.ciiva.com/11821/0900766b80f806dd-11821174.pdf; ComponentLink2Description: https://datasheet.ciiva.com/22758/1500035-22758198.pdf; ComponentLink2URL: https://datasheet.ciiva.com/22758/1500035-22758198.pdf; ComponentLink3Description: https://datasheet.ciiva.com/34303/1986799-34303543.pdf; ComponentLink3URL: https://datasheet.ciiva.com/34303/1986799-34303543.pdf; ComponentLink4Description: https://datasheet.ciiva.com/24023/aoa0000ce1-37422-24023480.pdf; ComponentLink4URL: https://datasheet.ciiva.com/24023/aoa0000ce1-37422-24023480.pdf; ComponentLink5Description: https://datasheet.ciiva.com/16777/70260739-16777321.pdf; ComponentLink5URL: https://datasheet.ciiva.com/16777/70260739-16777321.pdf; ComponentLink6Description: https://datasheet.ciiva.com/16759/70260739-16759692.pdf; ComponentLink6URL: https://datasheet.ciiva.com/16759/70260739-16759692.pdf; ComponentLink7Description: https://datasheet.ciiva.com/48531/aoa0000ce1-48531084.pdf; ComponentLink7URL: https://datasheet.ciiva.com/48531/aoa0000ce1-48531084.pdf; ComponentLink8Description: https://datasheet.ciiva.com/9186/aoa0000ce1-9186685.pdf; ComponentLink8URL: https://datasheet.ciiva.com/9186/aoa0000ce1-9186685.pdf; ComponentLink9Description: https://datasheet.ciiva.com/30204/4aoa0000ce1-30204557.pdf; ComponentLink9URL: https://datasheet.ciiva.com/30204/4aoa0000ce1-30204557.pdf; ComponentLink10Description: https://datasheet.ciiva.com/46389/1500035-46389645.pdf; ComponentLink10URL: https://datasheet.ciiva.com/46389/1500035-46389645.pdf; Composition: Thick Film; Contact Plating: Tin; Depth: 0.5mm; Features: Automotive AEC-Q200; Height: 0.35mm; Lead Free: Lead Free; Length: 1mm; Max Operating Temperature: 155°C; Military Standard: Not; Min Operating Temperature: -55°C; Mount: Surface Mount; Number of Terminations: 2; Package Quantity: 1; Packaging: Tape and Reel; Power Rating: 100mW; Pricing 1: 1=0.1, 10=0.038, 100=0.0154, 1000=0.0069, 2500=0.006, 5000=0.005 (USD); Radiation Hardening: No; REACH SVHC: No SVHC; Resistance: 100k; Stock 1: 10583442; Supplier 1: Digi-Key; Supplier Part Number 1: P100KJCT-ND; Termination: SMD/SMT; Tolerance: 5%; Voltage Rating: 50V; Voltage Rating (DC): 50V; Width: 0.02inch; Library Name: Plumo-2D.IntLib
R11 R24 = 0402R-NS: Resistor 0402 No Stuff; Tolerance: 1%; Case/Package: 0402; Case Code (Imperial): 0402; Case Code (Metric): 1005; Composition: Thick Film; Contact Plating: Tin,Matte; Height: 0.35mm; Lead Free: Lead Free; Length: 1mm; Max Operating Temperature: 155°C; Min Operating Temperature: -55°C; Radiation Hardening: No; Termination: SMD/SMT; Value: NS; Width: 0.5mm; Library Name: Plumo-2D.IntLib
R12 R13 R28 R29 = 0402R-10k: Resistor 10K 50V 5% 0402; Manufacturer: Vishay Dale; Manufacturer Part Number: CRCW040210K0JNED; item_number: E-RES-00001-00; Max Power Dissipation: 63mW; Temperature Coefficient: 200ppm/°C; Case/Package: 0402; Case Code (Imperial): 0402; Case Code (Metric): 1005; Component Kind: Standard; ComponentLink1Description: https://datasheet.ciiva.com/2461/dcrcwe3-2461974.pdf; ComponentLink1URL: https://datasheet.ciiva.com/2461/dcrcwe3-2461974.pdf; ComponentLink2Description: https://datasheet.ciiva.com/2461/dcrcwe3-2461975.pdf; ComponentLink2URL: https://datasheet.ciiva.com/2461/dcrcwe3-2461975.pdf; ComponentLink3Description: https://datasheet.ciiva.com/2461/dcrcwe3-2461976.pdf; ComponentLink3URL: https://datasheet.ciiva.com/2461/dcrcwe3-2461976.pdf; ComponentLink4Description: https://datasheet.ciiva.com/7743/dcrcwe3-7743416.pdf; ComponentLink4URL: https://datasheet.ciiva.com/7743/dcrcwe3-7743416.pdf; ComponentLink5Description: https://datasheet.ciiva.com/16771/70201119-16771096.pdf; ComponentLink5URL: https://datasheet.ciiva.com/16771/70201119-16771096.pdf; ComponentLink6Description: https://datasheet.ciiva.com/7743/dcrcwe3-7743417.pdf; ComponentLink6URL: https://datasheet.ciiva.com/7743/dcrcwe3-7743417.pdf; ComponentLink7Description: https://datasheet.ciiva.com/16761/70201119-16761947.pdf; ComponentLink7URL: https://datasheet.ciiva.com/16761/70201119-16761947.pdf; ComponentLink8Description: https://datasheet.ciiva.com/3255/dcrcwe3-3255964.pdf; ComponentLink8URL: https://datasheet.ciiva.com/3255/dcrcwe3-3255964.pdf; ComponentLink9Description: https://datasheet.ciiva.com/3255/dcrcwe3-3255965.pdf; ComponentLink9URL: https://datasheet.ciiva.com/3255/dcrcwe3-3255965.pdf; Composition: Thick Film; Contact Plating: Tin; Depth: 0.5mm; Features: Automotive AEC-Q200; Height: 0.016inch; Length: 1mm; Max Operating Temperature: 155°C; Military Standard: Not; Min Operating Temperature: -55°C; Mount: Surface Mount; Number of Terminations: 2; Package Quantity: 1; Packaging: Tape and Reel; Power Rating: 63mW; Pricing 1: 1=0.1, 10=0.031, 100=0.0125, 1000=0.0056, 2500=0.0049, 5000=0.004 (USD); Radiation Hardening: No; REACH SVHC: Unknown; Resistance: 10k; Stock 1: 0; Supplier 1: Digi-Key; Supplier Part Number 1: 541-10KJCT-ND; Termination: SMD/SMT; Tolerance: 5%; Voltage Rating: 50V; Weight: 2.3E-05oz; Width: 0.5mm; Library Name: Plumo-2D.IntLib
R15 R21 = 0402R-200k: Resistor 200K 50V 1% 0402; Manufacturer: Panasonic; Manufacturer Part Number: ERJ-2RKF2003X; item_number: E-RES-00054-00; Max Power Dissipation: 100mW; Temperature Coefficient: 200ppm/°C; Case/Package: 0402; Case Code (Imperial): 0402; Case Code (Metric): 1005; Component Kind: Standard; Composition: Thick Film; Contact Plating: Tin; Depth: 0.5mm; Features: Automotive AEC-Q200; Height: 0.35mm; Lead Free: Lead Free; Length: 1mm; Max Operating Temperature: 155°C; Military Standard: Not; Min Operating Temperature: -55°C; Mount: Surface Mount; Number of Terminations: 2; Package Quantity: 1; Packaging: Tape and Reel; Power Rating: 100mW; Radiation Hardening: No; REACH SVHC: No SVHC; Resistance: 200K; Termination: SMD/SMT; Tolerance: 1%; Voltage Rating: 50V; Voltage Rating (DC): 50V; Width: 0.02inch; Library Name: Plumo-2D.IntLib
R16 = 0402R-680k: Resistor 680K 50V 5% 0402; Manufacturer: Panasonic; Manufacturer Part Number: ERJ-2GEJ684X; item_number: E-RES-00093-00; Max Power Dissipation: 100mW; Temperature Coefficient: 200ppm/°C; Case/Package: 0402; Case Code (Imperial): 0402; Case Code (Metric): 1005; Component Kind: Standard; Composition: Thick Film; Contact Plating: Tin; Depth: 0.5mm; Features: Automotive AEC-Q200; Height: 0.35mm; Lead Free: Lead Free; Length: 1mm; Max Operating Temperature: 155°C; Military Standard: Not; Min Operating Temperature: -55°C; Mount: Surface Mount; Number of Terminations: 2; Package Quantity: 1; Packaging: Tape and Reel; Power Rating: 100mW; Radiation Hardening: No; REACH SVHC: No SVHC; Resistance: 680k; Stock 1: 10583442; Supplier 1: Digi-Key; Supplier Part Number 1: P100KJCT-ND; Termination: SMD/SMT; Tolerance: 5%; Voltage Rating: 50V; Voltage Rating (DC): 50V; Width: 0.02inch; Library Name: Plumo-2D.IntLib
R23 = 0402R-0R0: Resistor 0R 50V 1% 0402; Manufacturer: Yageo; Manufacturer Part Number: RC0402FR-070RL; item_number: E-RES-00006-00; Power Rating: 62.5mW; Resistance: 0mR; Temperature Coefficient: 200ppm/°C; Case/Package: 0402; Case Code (Imperial): 0402; Case Code (Metric): 1005; Component Kind: Standard; ComponentLink1Description: https://datasheet.ciiva.com/8896/pyu-rc0402-51-rohs-l-6-8896149.pdf; ComponentLink1URL: https://datasheet.ciiva.com/8896/pyu-rc0402-51-rohs-l-6-8896149.pdf; ComponentLink2Description: https://datasheet.ciiva.com/16790/pyu-rc0402-51-rohs-l-6-r-16790444.pdf; ComponentLink2URL: https://datasheet.ciiva.com/16790/pyu-rc0402-51-rohs-l-6-r-16790444.pdf; ComponentLink3Description: https://datasheet.ciiva.com/28419/pyu-rc0402-51-rohs-l-6-28419661.pdf; ComponentLink3URL: https://datasheet.ciiva.com/28419/pyu-rc0402-51-rohs-l-6-28419661.pdf; ComponentLink4Description: https://datasheet.ciiva.com/16869/pyu-rc0402-51-rohs-l-6-16869518.pdf; ComponentLink4URL: https://datasheet.ciiva.com/16869/pyu-rc0402-51-rohs-l-6-16869518.pdf; Composition: Thick Film; Contact Plating: Tin,Matte; Height: 0.35mm; Lead Free: Lead Free; Length: 1mm; Max Operating Temperature: 155°C; Min Operating Temperature: -55°C; Packaging: Tape and Reel; Pricing 1: 1=0.1, 10=0.01, 50=0.01, 100=0.003, 1000=0.002, 10000=0.002 (USD); Radiation Hardening: No; Stock 1: 240535; Supplier 1: Mouser; Supplier Part Number 1: 603-RC0402FR-070RL; Termination: SMD/SMT; Tolerance: 1%; Value: 0; Voltage Rating: 50V; Width: 0.5mm; Library Name: Plumo-2D.IntLib
R25-R27 = 0402R-1k: Resistor 1K 50V 5% 0402; Manufacturer: Panasonic; Manufacturer Part Number: ERJ-2GEJ102X; item_number: E-RES-00002-00; Max Power Dissipation: 100mW; Temperature Coefficient: 50ppm/°C; Case/Package: 0402; Case Code (Imperial): 0402; Case Code (Metric): 1005; Component Kind: Standard; Composition: Thin Film; Contact Plating: Tin,Lead,Matte; Depth: 0.5mm; Height: 0.014inch; Lead Free: Lead Free; Length: 1mm; Max Operating Temperature: 125°C; Military Standard: Not; Min Operating Temperature: -55°C; Number of Terminations: 2; Package Quantity: 10000; Packaging: Tape and Reel; Power Rating: 100mW; Pricing 1: 1=0.1, 10=0.076, 100=0.029, 1000=0.0128, 2500=0.011, 5000=0.0099 (USD); Radiation Hardening: No; Resistance: 1k; Termination: SMD/SMT; Tolerance: 5%; Voltage Rating: 50V; Voltage Rating (DC): 50V; Width: 0.02inch; Library Name: Plumo-2D.IntLib
S1 = KMR231NGLFS: SMD Tact Switch; Manufacturer: ITT C&K; Actuator Length: 0.5mm; Actuator Type: Standard; Current Rating: 50mA; Illumination Type: Non-Illuminated; item_number: E-SWI-00022; Max Voltage Rating (DC): 32V; Mechanical Life: 150000Cycles; Operating Force: 3N; Power Rating: 1W; Switch Function: Off-Mom; Switch Travel: 0.25mm; Throw Configuration: SPST; Case/Package: SMD/SMT; Component Kind: Standard; ComponentLink1Description: https://www.ckswitches.com/media/1479/kmr2.pdf; ComponentLink1URL: https://www.ckswitches.com/media/1479/kmr2.pdf; ComponentLink2Description: http://www.ck-components.com/14414/kmr2_9aug12.pdf/; ComponentLink2URL: http://www.ck-components.com/14414/kmr2_9aug12.pdf/; Contact Material: Silver; Contact Plating: Silver; Depth: 2.8mm; Length: 2.8mm; Manufacturer Part Number: KMR231NGLFS; Max Current Rating: 50mA; Max Operating Temperature: 85°C; Min Operating Temperature: -40°C; Mount: Surface Mount; Orientation: Straight; Package Quantity: 7000; Packaging: Tape and Reel; Pricing 1: 1=0.52, 10=0.499, 25=0.4896, 50=0.4712, 100=0.4065, 250=0.3696, 500=0.3511, 1000=0.2772 (USD); Radiation Hardening: No; Stock 1: 45821; Supplier 1: Digi-Key; Supplier Part Number 1: CKN10246CT-ND; Termination: Gull Wing; Voltage Rating (DC): 32V; Library Name: Plumo-2D.IntLib
SB1-SB7 = SBNC: Solderbridge, Normally Closed; Part Number: Solderbridge Shorted; Artikkelnr Noca: N.A.; Artikkelnr Simpro: N.A.; Component Kind: Net Tie; Footprint Doc: Solderbridge_Shorted; LatestRevisionDate: 2012-08-07; Pin Count: 2; Value: Solderbridge; Library Name: Plumo-2D.IntLib
TP1-TP7 TP9-TP11 TP13-TP15 = TP1MM: 1mm Test Point; item_number: item_name; Library Name: Plumo-2D.IntLib
U1 = IPS6404L-SQ: Memory SPI/QPI PSRAM 8MB SOIC8 150mil; Manufacturer: IPUS; Manufacturer Part Number: IPS6404L-SQ-SPN; item_number: E-ICS-00099-00; Component Kind: Standard; Package / Case: 8 SOIC 150mil; Library Name: Plumo-2D.IntLib
U2 = ATECC508A: Afero Custom CryptoAuthentication Device; Manufacturer: Microchip / Atmel; item_number: E-ICS-00072-00; Component Kind: Standard; Max Operating Temperature: 85°C; Min Operating Temperature: -40°C; Mount: Surface Mount; Packaging: Tape and Reel; Pricing 1: 1=0.35, 25=0.32, 100=0.29 (USD); Stock 1: 4638; Supplier 1: Digi-Key; Supplier Part Number 1: ATECC508A-MAHDA-TCT-ND; Library Name: Plumo-2D.IntLib
U3 = XC9264B755MR: Voltage Regulator 0.5A 500KHz Buck; Manufacturer: Torex; item_number: E-ICS-00096-00; Component Kind: Standard; Manufacturer Part Number: XC9264B755MR; Package / Case: SOT25; Library Name: Plumo-2D.IntLib
U4 = CP2104: USB to UART Bridge; Manufacturer: SiLabs; Manufacturer Part Number: CP2104-F03-GM; item_number: E-ICS-00098-00; Component Kind: Standard; Library Name: Plumo-2D.IntLib
X1 = ABS06-32.768KHZ-T: Crystal, 32.768kHz ±20ppm; Manufacturer: Abracon; ESR (Equivalent Series Resistance): 90kR; Insulation Resistance: 500MR; item_number: E-XTL-00012-00; Load Capacitance: 12.5pF; Case/Package: SMD/SMT; Component Kind: Standard; ComponentLink1Description: http://www.farnell.com/datasheets/1796520.pdf; ComponentLink1URL: http://www.farnell.com/datasheets/1796520.pdf; ComponentLink2Description: https://abracon.com/Support/PackageDrawing/Resonators/ABS06.PDF; ComponentLink2URL: https://abracon.com/Support/PackageDrawing/Resonators/ABS06.PDF; ComponentLink3Description: http://www.farnell.com/datasheets/1912788.pdf; ComponentLink3URL: http://www.farnell.com/datasheets/1912788.pdf; ComponentLink4Description: http://www.abracon.com/Resonators/ABS06.pdf; ComponentLink4URL: http://www.abracon.com/Resonators/ABS06.pdf; Depth: 1.2mm; Frequency: 32.768kHz; Frequency Stability: 20ppm; Frequency Tolerance: 20ppm; Height: 0.024inch; Lead Free: Lead Free; Length: 2mm; Manufacturer Part Number: ABS06-32.768KHZ-T; Max Operating Temperature: 85°C; Min Operating Temperature: -40°C; Mount: Surface Mount; Number of Pins: 2; Package Quantity: 3000; Packaging: Tape and Reel; Pricing 1: 1=1.34, 10=1.277, 50=1.2474, 100=1.0692, 500=1.0395, 1000=0.8762 (USD); Radiation Hardening: No; REACH SVHC: No SVHC; Series Resistance: 90kR; Stock 1: 65982; Supplier 1: Digi-Key; Supplier Part Number 1: 535-10104-1-ND; Termination: SMD/SMT; Tolerance: 20ppm; Width: 1.2mm; Library Name: Plumo-2D.IntLib
